# Altium schematic sheet Stepper.SchDoc
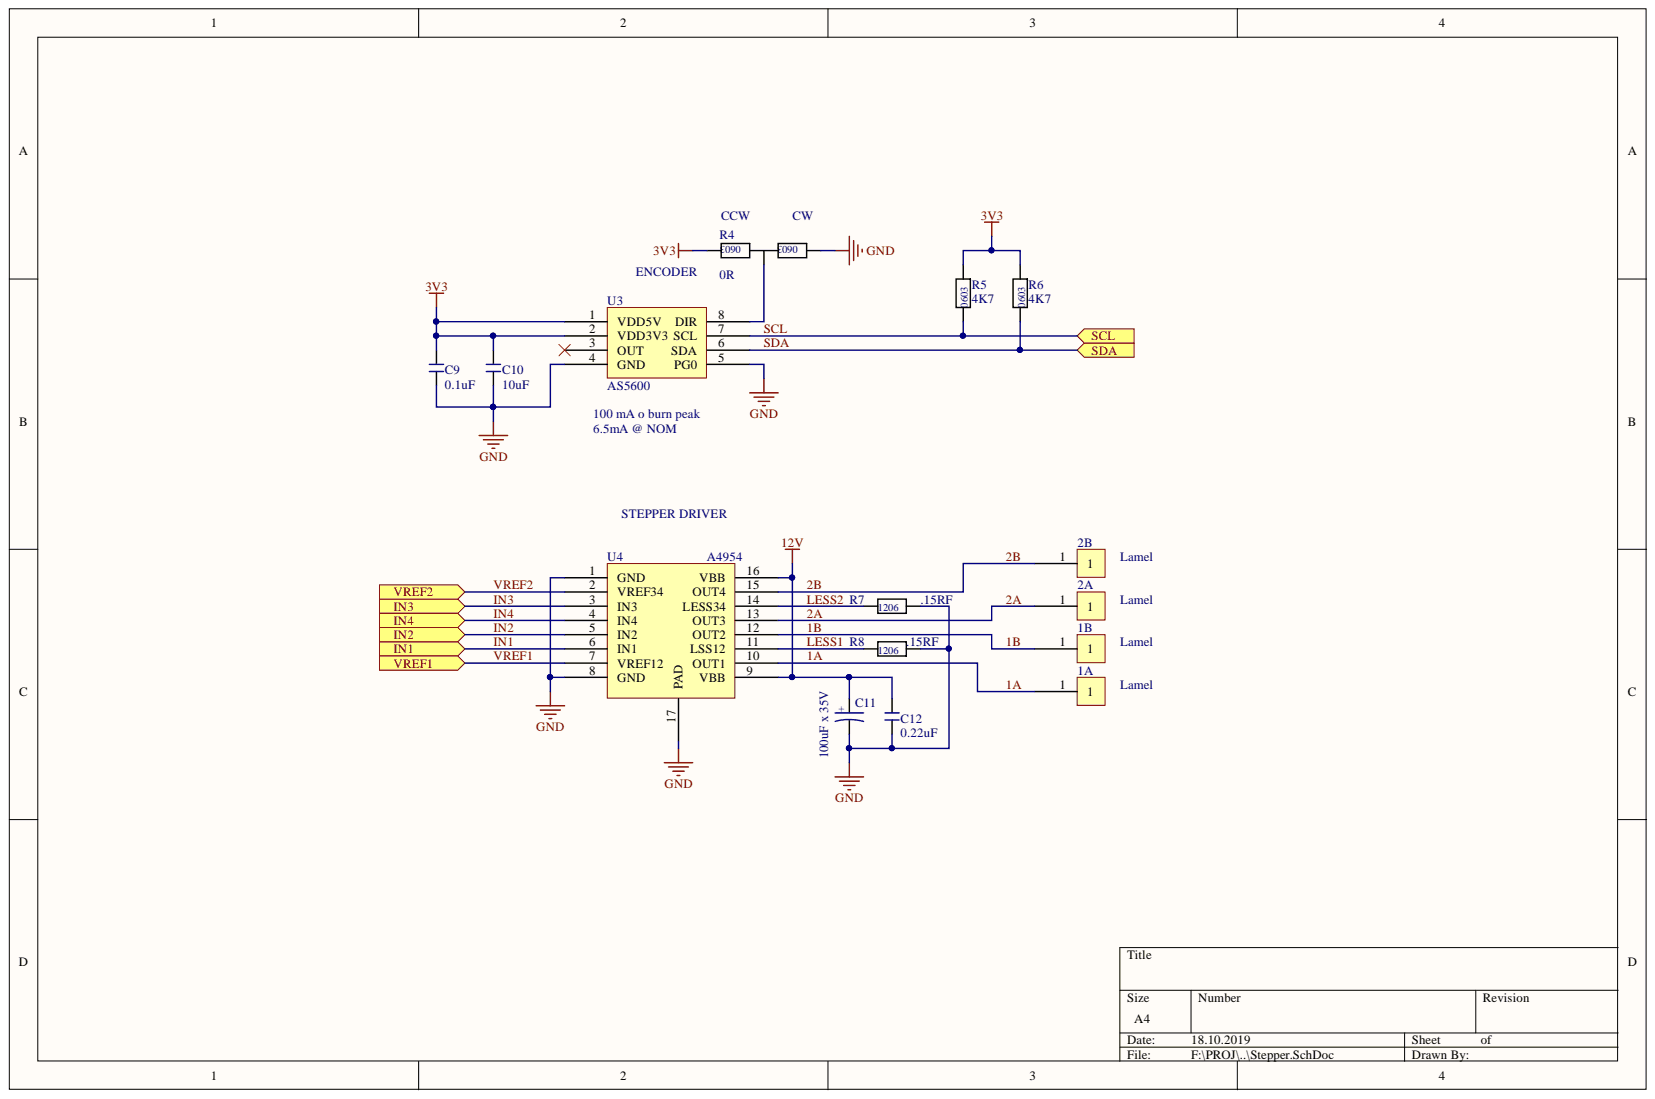
[diagram p0: Stepper.SchDoc]

## Parts
1A  Lamel  [LAMEL]
1B  Lamel  [LAMEL]
2A  Lamel  [LAMEL]
2B  Lamel  [LAMEL]
C9  0.1uF  [CHIP_C_0603]
C10  10uF  [CHIP_C_0603]
C11  100uF x 35V  [ALUM_CAP_D]
C12  0.22uF  [CHIP_C_0603]
R4  0R  [0R 0603 selector]
R5  4K7  [CHIP_R_0603]
R6  4K7  [CHIP_R_0603]
R7  .15RF  [CHIP_R_1206]
R8  .15RF  [CHIP_R_1206]
U3  AS5600  [SOIC8]
U4  A4954  [TSSOP-16P]

## Ports
IN1, IN2, IN3, IN4, SCL, SDA, VREF1, VREF2

## Nets
3V3: C9.2 C10.2 R4.1 R5.2 R6.2 U3.1(VDD5V) U3.2(VDD3V3)
12V: C11.2 C12.2 U4.9(VBB) U4.16(VBB)
GND: C9.1 C10.1 C11.1 C12.1 R4.3 R7.2 R8.2 U3.4(GND) U3.5(PG0) U4.1(GND) U4.8(GND) U4.17(PAD)
1A: 1A.1 U4.10(OUT1)
1B: 1B.1 U4.12(OUT2)
2A: 2A.1 U4.13(OUT3)
2B: 2B.1 U4.15(OUT4)
IN1: U4.6(IN1) ; port IN1
IN2: U4.5(IN2) ; port IN2
IN3: U4.3(IN3) ; port IN3
IN4: U4.4(IN4) ; port IN4
LESS1: R8.1 U4.11(LSS12)
LESS2: R7.1 U4.14(LESS34)
SCL: R5.1 U3.7(SCL) ; port SCL
SDA: R6.1 U3.6(SDA) ; port SDA
VREF1: U4.7(VREF12) ; port VREF1
VREF2: U4.2(VREF34) ; port VREF2
NetR4_2: R4.2 U3.8(DIR)

Not connected: U3 3(OUT)

## Library data of the parts
C9 C10 C12 = CHIP_C: Component Kind: Standard; Library Name: Popular.SchLib
C11 = Electrolit: Component Kind: Standard; Library Name: Popular.SchLib
R4 = Selector_0603: Component Kind: Standard; Library Name: Popular.SchLib
R5 R6 = RES_0603: Component Kind: Standard; Library Name: Popular.SchLib
R7 R8 = RES_1206: Resistor; Component Kind: Standard; Library Name: Popular.SchLib
U3 = AS5600: program magnetic rotary position  sensor; Component Kind: Standard; Library Name: Popular.SchLib
U4 = A4954: DMOS PWM Motor Driver; Component Kind: Standard; Library Name: Popular.SchLib
1A 1B 2A 2B = Lamel: Component Kind: Standard; Library Name: Popular.SchLib
